# Altium schematic sheet DCDC_Jetson.SchDoc(DCDC_Jetson)
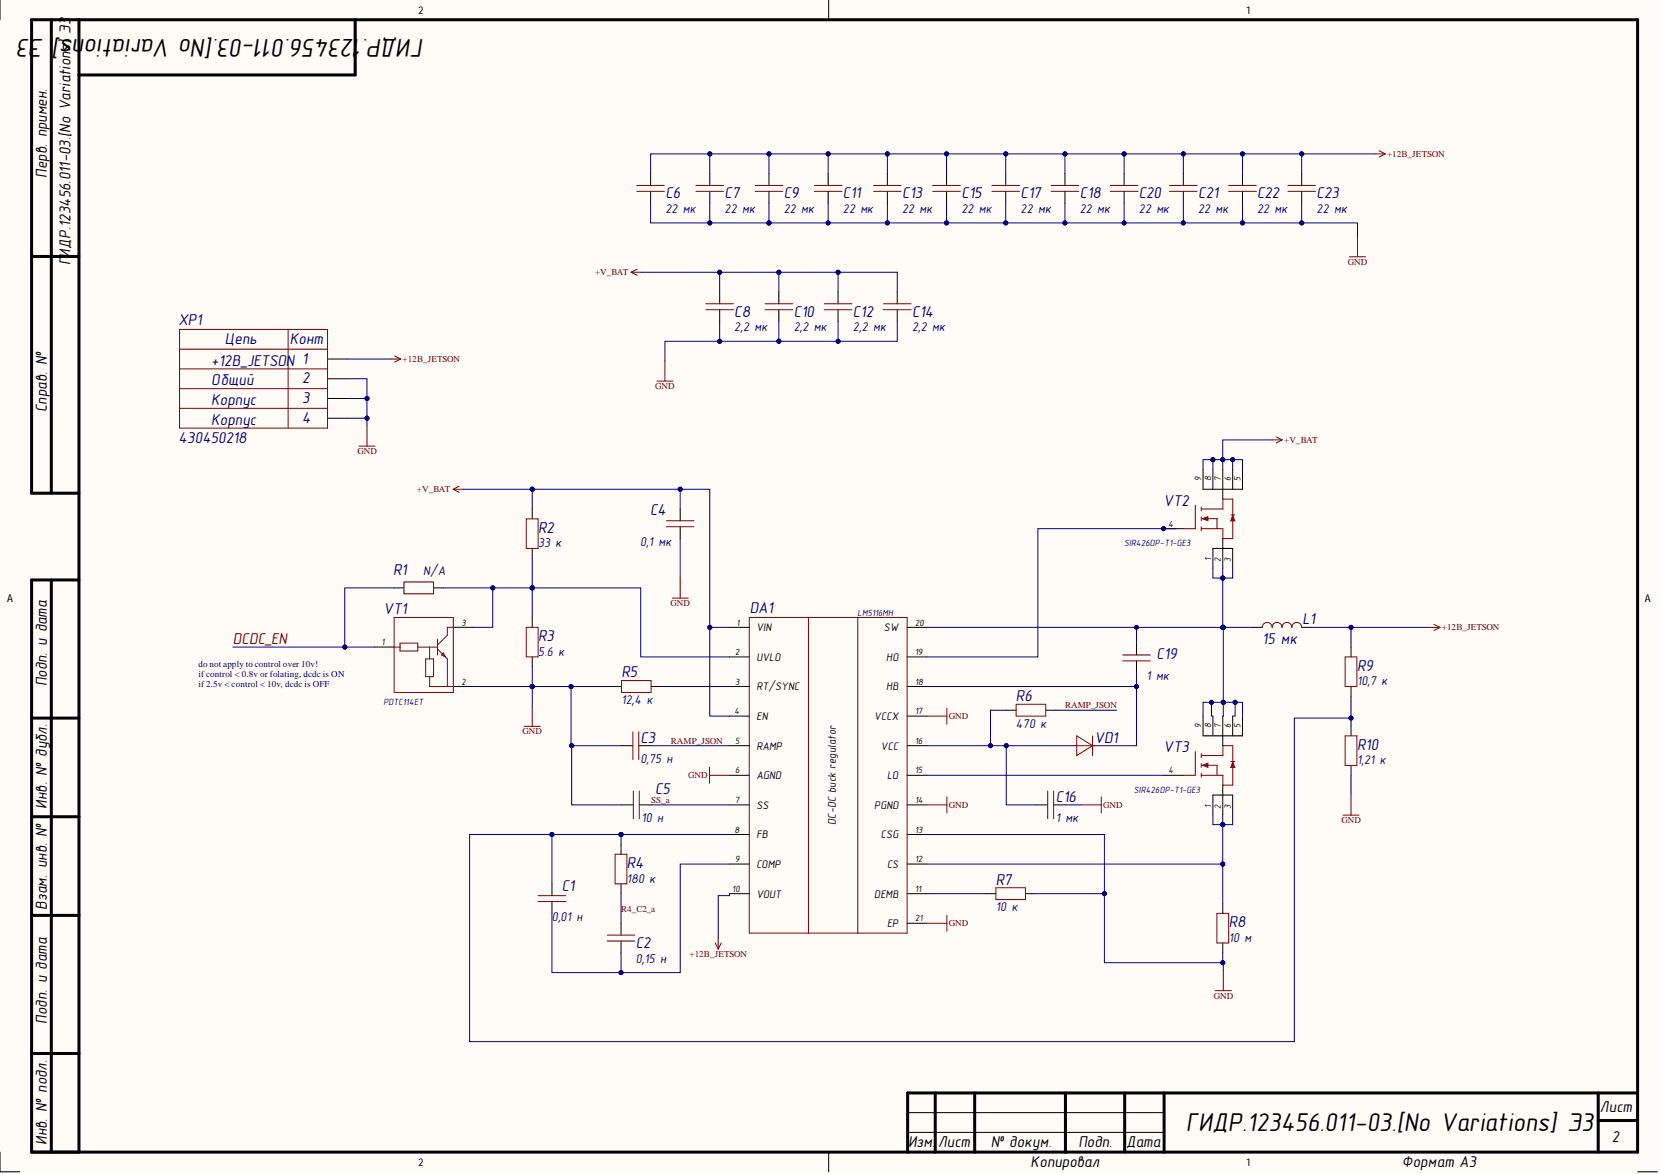
[diagram p0: DCDC_Jetson.SchDoc]

## Parts
C1  value 0,01 н  Capacity (nF): 0,01  [0603C]  (hidden comment: Capacitor)
C2  value 0,15 н  Capacity (nF): 0,15  [0603C]  (hidden comment: Capacitor)
C3  value 0,75 н  Capacity (nF): 0,75  [0603C]  (hidden comment: Capacitor)
C4  value 0,1 мк  Tolerance: 0,1  [0603C]  (hidden comment: Capacitor)
C5  value 10 н  [0603C]  (hidden comment: Capacitor)
C6  Capacitor  [1210C]  (value not drawn)
C7  value 22 мк  [1210C]  (hidden comment: Capacitor)
C8  Capacitor  [1206C]  (value not drawn)
C9  value 22 мк  [1210C]  (hidden comment: Capacitor)
C10  value 2,2 мк  [1206C]  (hidden comment: Capacitor)
C11  value 22 мк  [1210C]  (hidden comment: Capacitor)
C12  value 2,2 мк  [1206C]  (hidden comment: Capacitor)
C13  value 22 мк  [1210C]  (hidden comment: Capacitor)
C14  value 2,2 мк  [1206C]  (hidden comment: Capacitor)
C15  value 22 мк  [1210C]  (hidden comment: Capacitor)
C16  value 1 мк  [0603C]  (hidden comment: Capacitor)
C17  value 22 мк  [1210C]  (hidden comment: Capacitor)
C18  value 22 мк  [1210C]  (hidden comment: Capacitor)
C19  value 1 мк  [0603C]  (hidden comment: Capacitor)
C20  value 22 мк  [1210C]  (hidden comment: Capacitor)
C21  value 22 мк  [1210C]  (hidden comment: Capacitor)
C22  value 22 мк  [1210C]  (hidden comment: Capacitor)
C23  value 22 мк  [1210C]  (hidden comment: Capacitor)
DA1  LM5116MH  [HTSSOP-20]
L1  value 15 мк  [SPRH127]  (hidden comment: CDSPRH127-150M)
R1  Resistor  [0603R]  (value not drawn)
R2  value 33 к  [0603R]  (hidden comment: Resistor)
R3  value 5.6 к  [0603R]  (hidden comment: Resistor)
R4  value 180 к  [0603R]  (hidden comment: Resistor)
R5  value 12,4 к  [0603R]  (hidden comment: Resistor)
R6  value 470 к  [0603R]  (hidden comment: Resistor)
R7  value 10 к  [0603R]  (hidden comment: Resistor)
R8  value 10 м  [1210R]  (hidden comment: Resistor)
R9  value 10,7 к  [0603R]  (hidden comment: Resistor)
R10  value 1,21 к  [0603R]  (hidden comment: Resistor)
VD1  ES1D-E3/61T  [SMA]  (value not drawn)
VT1  PDTC114ET  [SOT23-3]
VT2  SIR426DP-T1-GE3  [PowerPAK_SO-8]
VT3  SIR426DP-T1-GE3  [PowerPAK_SO-8]
XP1  430450218  Value1: +12В_JETSON  Value2: Общий  Value3: Корпус  [Molex_430450218]

## Nets
+12В_JETSON: C6.2 C7.2 C9.2 C11.2 C13.2 C15.2 C17.2 C18.2 C20.2 C21.2 C22.2 C23.2 DA1.10(VOUT) L1.2 R9.2 XP1.1
+V_BAT: C4.2 C8.2 C10.2 C12.2 C14.2 DA1.1(VIN) DA1.4(EN) R2.2 VT2.5 VT2.6 VT2.7 VT2.8 VT2.9
GND: C3.1 C4.1 C5.1 C6.1 C7.1 C8.1 C9.1 C10.1 C11.1 C12.1 C13.1 C14.1 C15.1 C16.2 C17.1 C18.1 C20.1 C21.1 C22.1 C23.1 DA1.6(AGND) DA1.13(CSG) DA1.14(PGND) DA1.17(VCCX) DA1.21(EP) R3.1 R5.1 R7.2 R8.1 R10.1 VT1.2 XP1.0 XP1.2
DCDC_EN: R1.1 VT1.1
R4_C2_a: C2.2 R4.1
RAMP_JSON: C3.2 DA1.5(RAMP) R6.2
SS_a: C5.2 DA1.7(SS)
NetC1_1: C1.1 C2.1 DA1.9(COMP)
NetC1_2: C1.2 DA1.8(FB) R4.2 R9.1 R10.2
NetC16_1: C16.1 DA1.16(VCC) R6.1 VD1.1
NetC19_1: C19.1 DA1.18(HB) VD1.2
NetC19_2: C19.2 DA1.20(SW) L1.1 VT2.1 VT2.2 VT2.3 VT3.5 VT3.6 VT3.7 VT3.8 VT3.9
NetDA1_2: DA1.2(UVLO) R1.2 R2.1 R3.2 VT1.3
NetDA1_3: DA1.3(RT/SYNC) R5.2
NetDA1_11: DA1.11(DEMB) R7.1
NetDA1_12: DA1.12(CS) R8.2 VT3.1 VT3.2 VT3.3
NetDA1_15: DA1.15(LO) VT3.4
NetDA1_19: DA1.19(HO) VT2.4

## Library data of the parts
C1 = Capacitor_0,01n_10_50V_X7R_0603C: Конденсатор; Database Table Name: Capacitors_ceramic$; Dielectric: X7R; Library Path2: Schematic\Capacitor.SchLib; Tolerance: 0,1; Voltage (V): 50; Component Kind: Standard; Library Name: Hydrolib.DbLib; Part Number: Capacitor_0,01n_10_50V_X7R_0603C
C2 = Capacitor_0,15n_10_50V_X7R_0603C: Конденсатор; Database Table Name: Capacitors_ceramic$; Dielectric: X7R; Library Path2: Schematic\Capacitor.SchLib; Tolerance: 0,1; Voltage (V): 50; Component Kind: Standard; Library Name: Hydrolib.DbLib; Part Number: Capacitor_0,15n_10_50V_X7R_0603C
C3 = Capacitor_0,75n_10_50V_X7R_0603C: Конденсатор; Database Table Name: Capacitors_ceramic$; Dielectric: X7R; Library Path2: Schematic\Capacitor.SchLib; Tolerance: 0,1; Voltage (V): 50; Component Kind: Standard; Library Name: Hydrolib.DbLib; Part Number: Capacitor_0,75n_10_50V_X7R_0603C
C4 = Capacitor_0,1u_10_50V_X7R_0603C: Конденсатор; Capacity (nF): 100; Database Table Name: Capacitors_ceramic$; Dielectric: X7R; Library Path2: Schematic\Capacitor.SchLib; Voltage (V): 50; Component Kind: Standard; Library Name: Hydrolib.DbLib; Part Number: Capacitor_0,1u_10_50V_X7R_0603C
C5 = Capacitor_10n_10_50V_X7R_0603C: Конденсатор; Capacity (nF): 10; Database Table Name: Capacitors_ceramic$; Dielectric: X7R; Library Path2: Schematic\Capacitor.SchLib; Tolerance: 0,1; Voltage (V): 50; Component Kind: Standard; Library Name: Hydrolib.DbLib; Part Number: Capacitor_10n_10_50V_X7R_0603C
C6 = Capacitor_22u_10_25V_X7R_1210C: Конденсатор; Capacity (nF): 22000; Database Table Name: Capacitors_ceramic$; Dielectric: X7R; Library Path2: Schematic\Capacitor.SchLib; Tolerance: 0,1; Value: 22 мк; Voltage (V): 25; Component Kind: Standard; Library Name: Hydrolib.DbLib; Part Number: Capacitor_22u_10_25V_X7R_1210C
C7 C9 C11 C13 C15 C17 C18 C20-C23 = Capacitor_22u_10_25V_X7R_1210C: Конденсатор; Capacity (nF): 22000; Database Table Name: Capacitors_ceramic$; Dielectric: X7R; Library Path2: Schematic\Capacitor.SchLib; Tolerance: 0,1; Voltage (V): 25; Component Kind: Standard; Library Name: Hydrolib.DbLib; Part Number: Capacitor_22u_10_25V_X7R_1210C
C8 = Capacitor_2,2u_10_50V_X7R_1206C: Конденсатор; Capacity (nF): 2200; Database Table Name: Capacitors_ceramic$; Dielectric: X7R; Library Path2: Schematic\Capacitor.SchLib; Tolerance: 0,1; Value: 2,2 мк; Voltage (V): 50; Component Kind: Standard; Library Name: Hydrolib.DbLib; Part Number: Capacitor_2,2u_10_50V_X7R_1206C
C10 C12 C14 = Capacitor_2,2u_10_50V_X7R_1206C: Конденсатор; Capacity (nF): 2200; Database Table Name: Capacitors_ceramic$; Dielectric: X7R; Library Path2: Schematic\Capacitor.SchLib; Tolerance: 0,1; Voltage (V): 50; Component Kind: Standard; Library Name: Hydrolib.DbLib; Part Number: Capacitor_2,2u_10_50V_X7R_1206C
C16 C19 = Capacitor_1u_10_50V_X7R_0603C: Конденсатор; Capacity (nF): 1000; Database Table Name: Capacitors_ceramic$; Dielectric: X7R; Library Path2: Schematic\Capacitor.SchLib; Tolerance: 0,1; Voltage (V): 50; Component Kind: Standard; Library Name: Hydrolib.DbLib; Part Number: Capacitor_1u_10_50V_X7R_0603C
DA1 = LM5116MH: dc-dc понижающий adj 6-100v; Manufacturer_Part_Number: LM5116MH/NOPB; Database Table Name: Chips$; Component Kind: Standard; Library Name: Hydrolib.DbLib; Part Number: LM5116MH
L1 = CDSPRH127-150M: Индуктивность на 15 мкГн, номинальный ток 8,4 А; Current (A): 8,4; Database Table Name: Inductance$; Inductance (μH): 15; Component Kind: Standard; Library Name: Hydrolib.DbLib; Part Number: CDSPRH127-150M
R1 = res_0603_N/A: посадочное место 0603 под перемычку; Database Table Name: Resistors$; Tolerance: 1%; Component Kind: Standard; Library Name: Hydrolib.DbLib; Part Number: res_0603_N/A; Value: N/A
R2 = Resistor_33k_1_0603: Резистор номиналом 33 кОм и точностью 1%; Database Table Name: Resistors$; Component Kind: Standard; Library Name: Hydrolib.DbLib; Part Number: Resistor_33k_1_0603; Tolerance: 1%
R3 = Resistor_2.7k_1_0603: Резистор номиналом 2,7 кОм и точностью 1%; Database Table Name: Resistors$; Component Kind: Standard; Library Name: Hydrolib.DbLib; Part Number: Resistor_2.7k_1_0603; Tolerance: 1%
R4 = Resistor_180k_1_0603: Резистор номиналом 180 кОм и точностью 1%; Database Table Name: Resistors$; Component Kind: Standard; Library Name: Hydrolib.DbLib; Part Number: Resistor_180k_1_0603; Tolerance: 1%
R5 = Resistor_12.4k_1_0603: Резистор номиналом 12,4 кОм и точностью 1%; Database Table Name: Resistors$; Component Kind: Standard; Library Name: Hydrolib.DbLib; Part Number: Resistor_12.4k_1_0603; Tolerance: 1%
R6 = Resistor_470k_1_0603: Резистор номиналом 470 кОм и точностью 1%; Database Table Name: Resistors$; Component Kind: Standard; Library Name: Hydrolib.DbLib; Part Number: Resistor_470k_1_0603; Tolerance: 1%
R7 = Resistor_10k_1_0603: Резистор номиналом 10 кОм и точностью 1%; Database Table Name: Resistors$; Component Kind: Standard; Library Name: Hydrolib.DbLib; Part Number: Resistor_10k_1_0603; Tolerance: 1%
R8 = RL1210FR-070R01L: Резистор номиналом 10 мОм, точностью 1%, 0.5Вт; Database Table Name: Resistors$; Component Kind: Standard; Library Name: Hydrolib.DbLib; Part Number: RL1210FR-070R01L; Tolerance: 1%
R9 = Resistor_10.7k_1_0603: Резистор номиналом 10,7 кОм и точностью 1%; Database Table Name: Resistors$; Component Kind: Standard; Library Name: Hydrolib.DbLib; Part Number: Resistor_10.7k_1_0603; Tolerance: 1%
R10 = Resistor_1.21k_1_0603: Резистор номиналом 1,21 кОм и точностью 1%; Database Table Name: Resistors$; Component Kind: Standard; Library Name: Hydrolib.DbLib; Part Number: Resistor_1.21k_1_0603; Tolerance: 1%
VD1 = ES1D-E3/61T: Диод 1A 200V; Current (A): 1; Database Table Name: Rectifier Diodes$; Repetitive peak reverse voltage (Vrrm): 200; Component Kind: Standard; Library Name: Hydrolib.DbLib; Part Number: ES1D-E3/61T
VT1 = PDTC114ET: цифровой npn 50в 100мА; Database Table Name: Transistors$; Ток макс#: 0, 1 А; Component Kind: Standard; Library Name: Hydrolib.DbLib; Part Number: PDTC114ET; Напряжение С-И/К-Э: 50 В
VT2 VT3 = SIR426DP-T1-GE3: Транзистор полевой MOSFET N-канальный 40В 41.7Вт; Database Table Name: Transistors$; Component Kind: Standard; Library Name: Hydrolib.DbLib; Part Number: SIR426DP-T1-GE3; Напряжение С-И/К-Э: 40 В
XP1 = Molex_430450218: Разъем типа провод-плата, 3 мм, 2 контакт(-ов), Штыревой Разъем, Серия Micro-Fit для поверхностного монтажа; Database Table Name: Connectors$; Component Kind: Standard; Library Name: Hydrolib.DbLib; Part Number: Molex_430450218; Value4: Корпус
